# Altium schematic sheet MUX.SchDoc(MUX)
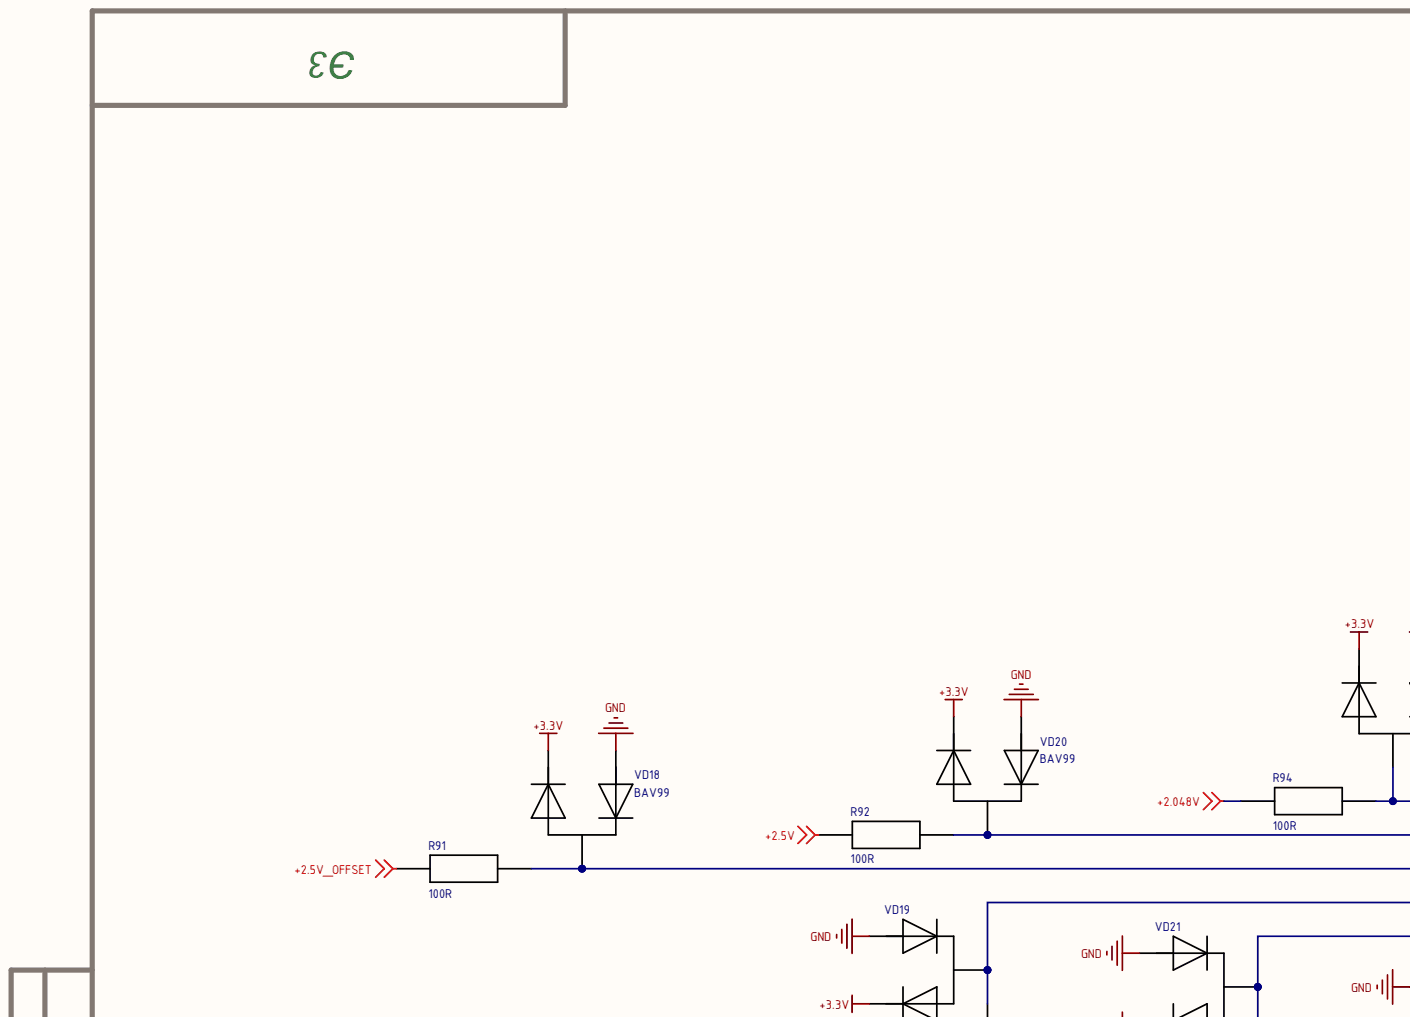
[diagram p0: MUX.SchDoc, top left]
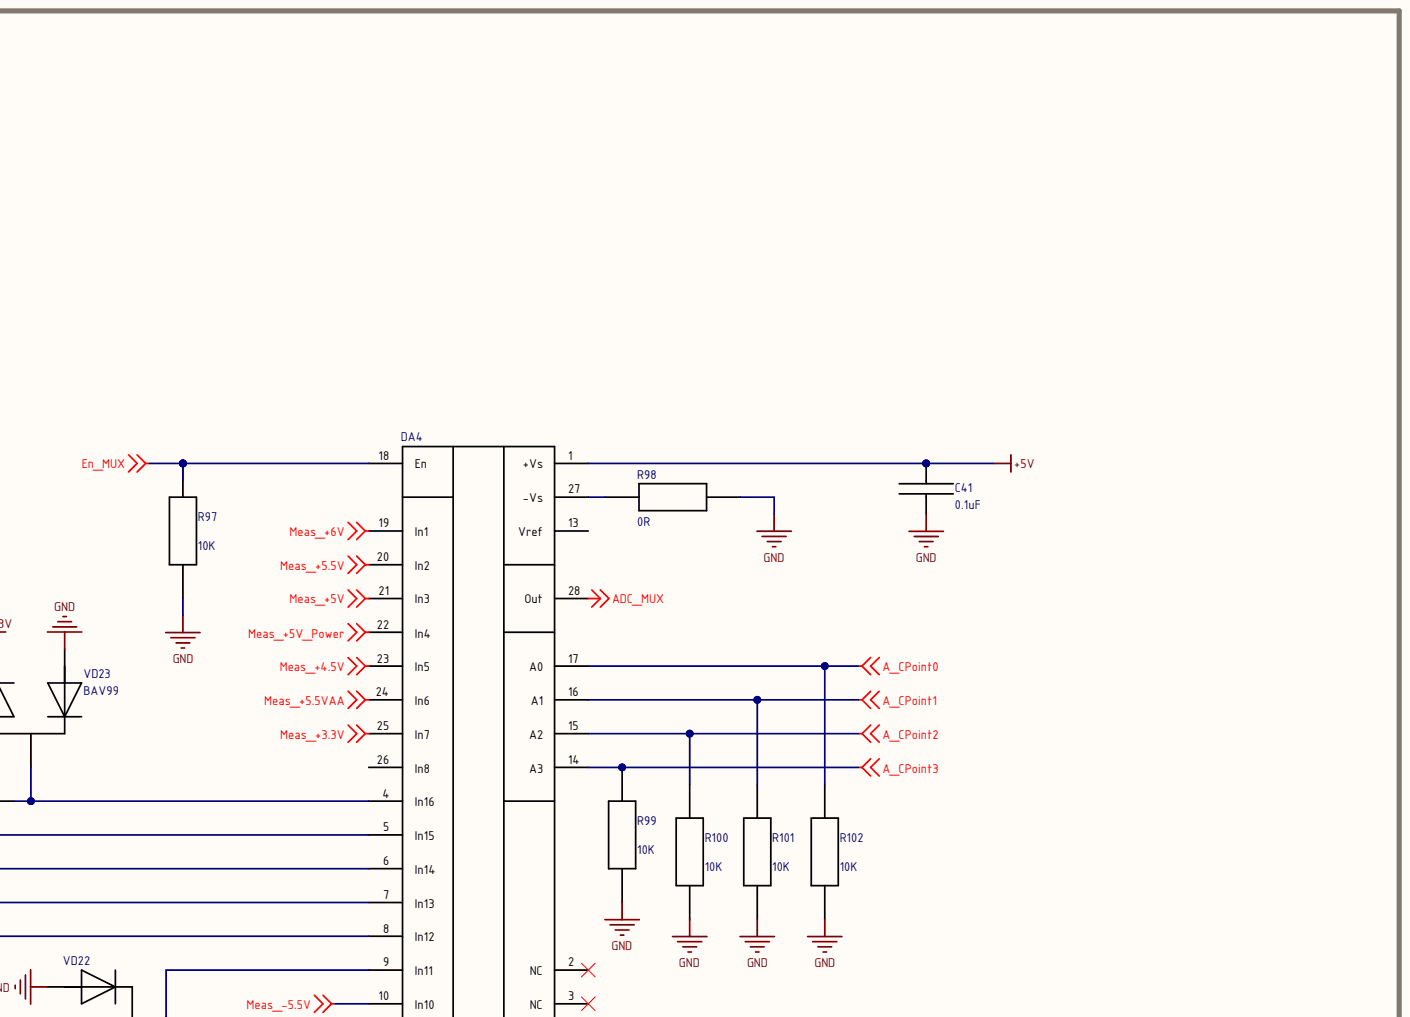
[diagram p1: MUX.SchDoc, top right]
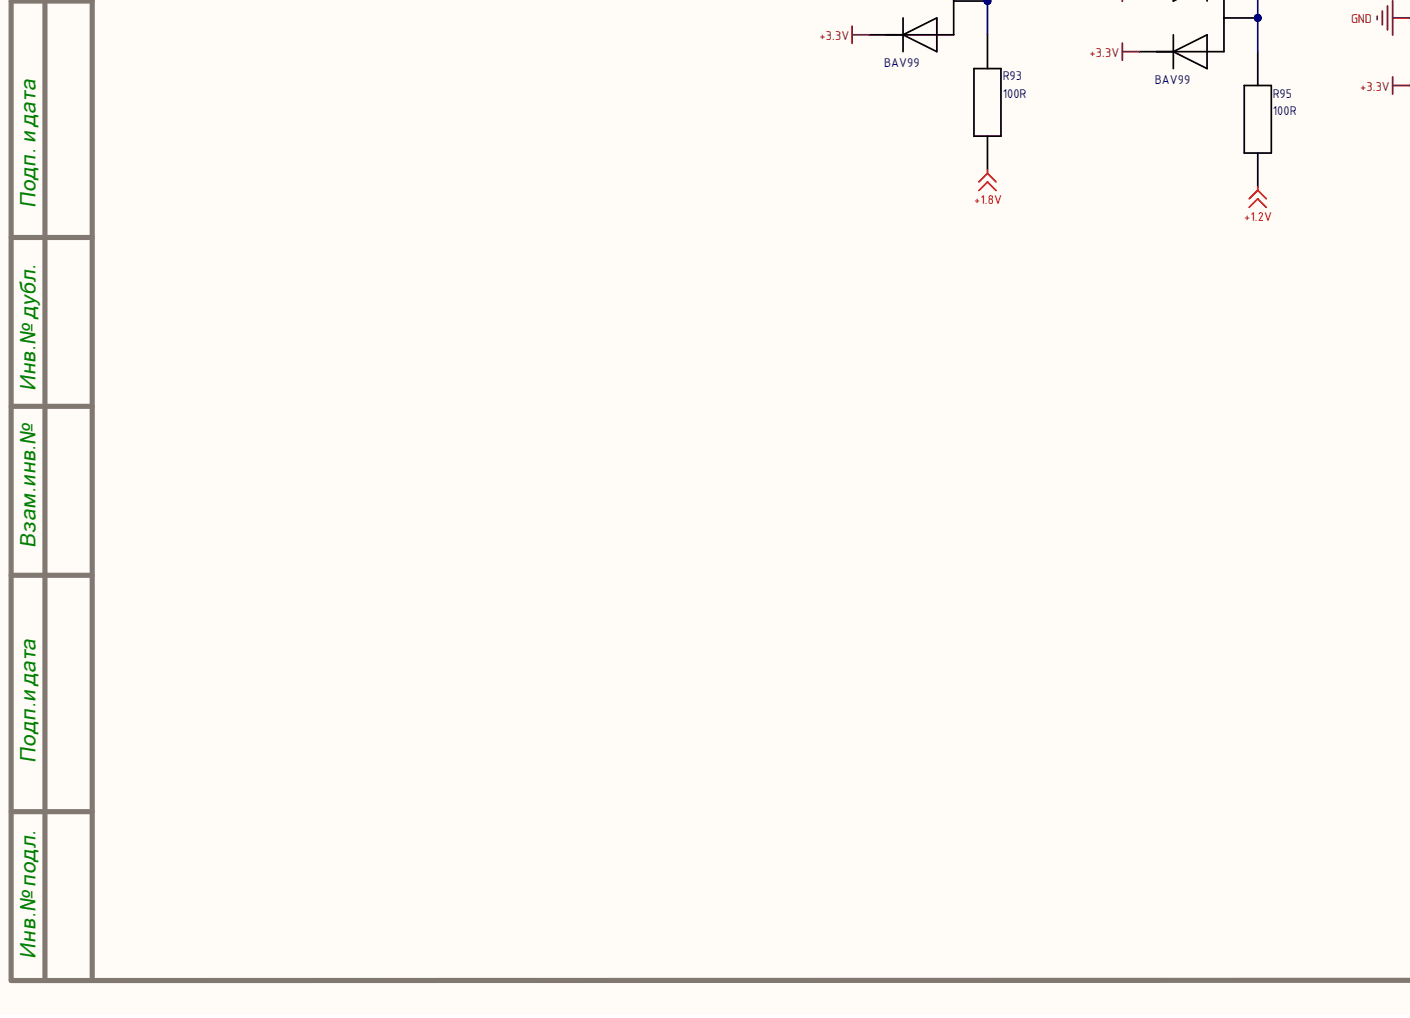
[diagram p2: MUX.SchDoc, bottom left]
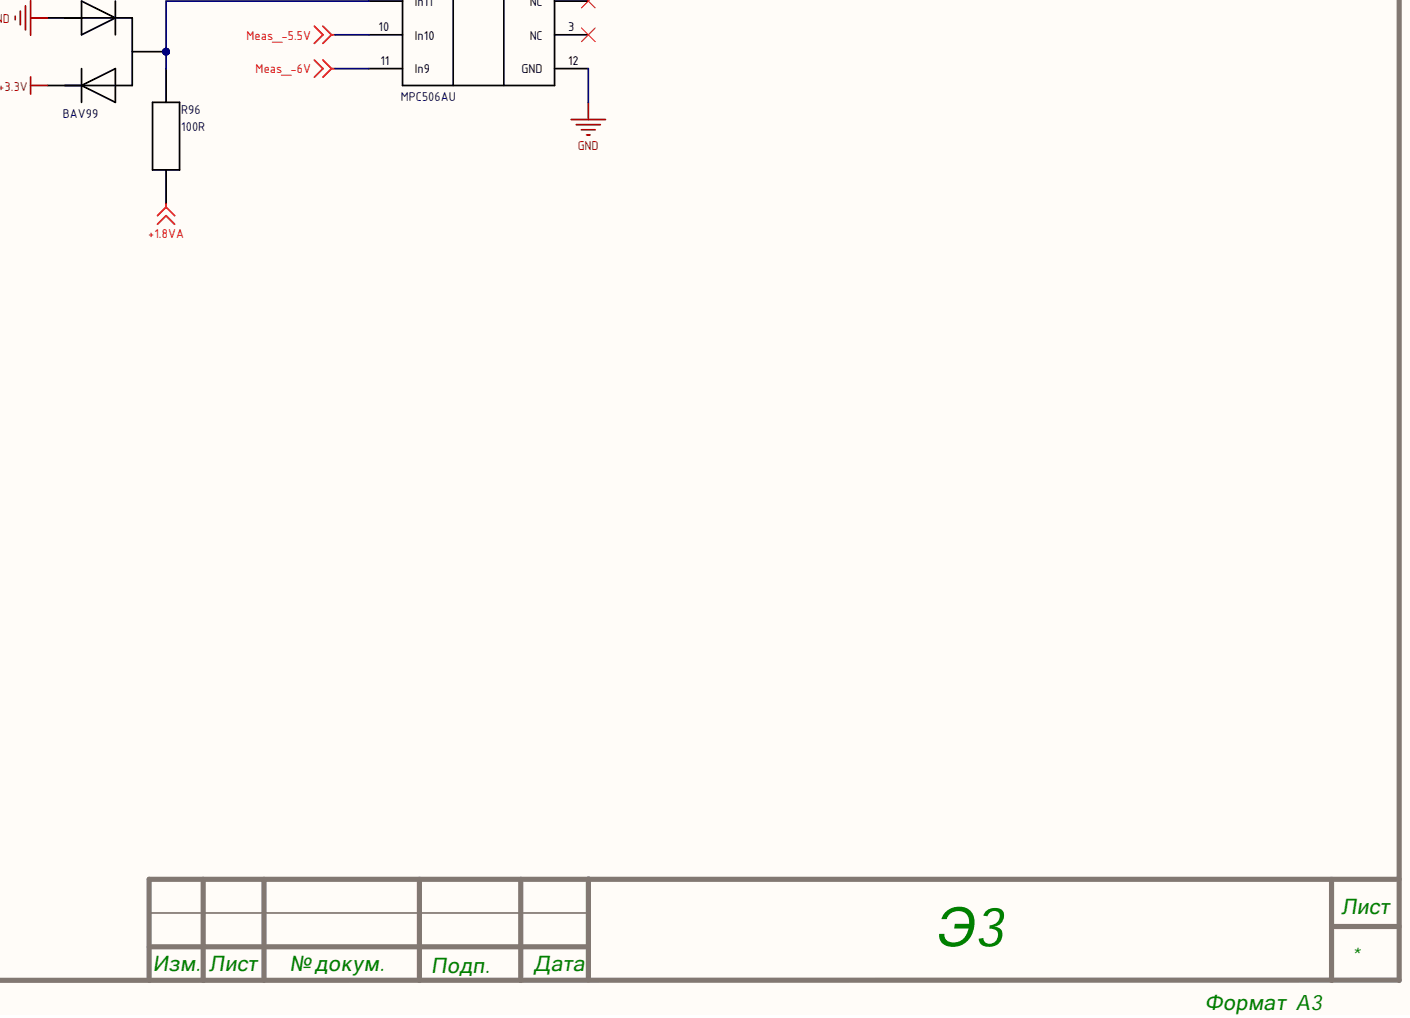
[diagram p3: MUX.SchDoc, bottom right]

## Parts
C41  0.1uF  [0603C]
DA4  MPC506AU  [SOIC-28]
R91  100R  [R0402]
R92  100R  [R0402]
R93  100R  [R0402]
R94  100R  [R0402]
R95  100R  [R0402]
R96  100R  [R0402]
R97  10K  [R0402]
R98  0R  [R0402]
R99  10K  [R0402]
R100  10K  [R0402]
R101  10K  [R0402]
R102  10K  [R0402]
VD18  BAV99  [SOT-23-3]
VD19  BAV99  [SOT-23-3]
VD20  BAV99  [SOT-23-3]
VD21  BAV99  [SOT-23-3]
VD22  BAV99  [SOT-23-3]
VD23  BAV99  [SOT-23-3]

## Nets
+1.2V: R95.1
+1.8V: R93.1
+1.8VA: R96.1
+2.5V: R92.1
+2.5V_OFFSET: R91.1
+2.048V: R94.1
+3.3V: VD18.2 VD19.2 VD20.2 VD21.2 VD22.2 VD23.2
+5V: C41.1 DA4.1(+Vs)
A_CPoint0: DA4.17(A0) R102.2
A_CPoint1: DA4.16(A1) R101.2
A_CPoint2: DA4.15(A2) R100.2
A_CPoint3: DA4.14(A3) R99.2
ADC_MUX: DA4.28(Out)
En_MUX: DA4.18(En) R97.1
GND: C41.2 DA4.12(GND) R97.2 R98.1 R99.1 R100.1 R101.1 R102.1 VD18.1 VD19.1 VD20.1 VD21.1 VD22.1 VD23.1
Meas_+3.3V: DA4.25(In7)
Meas_+4.5V: DA4.23(In5)
Meas_+5.5V: DA4.20(In2)
Meas_+5.5VAA: DA4.24(In6)
Meas_+5V: DA4.21(In3)
Meas_+5V_Power: DA4.22(In4)
Meas_+6V: DA4.19(In1)
Meas_-5.5V: DA4.10(In10)
Meas_-6V: DA4.11(In9)
NetDA4_4: DA4.4(In16) R94.2 VD23.3
NetDA4_5: DA4.5(In15) R92.2 VD20.3
NetDA4_6: DA4.6(In14) R91.2 VD18.3
NetDA4_7: DA4.7(In13) R93.2 VD19.3
NetDA4_8: DA4.8(In12) R95.2 VD21.3
NetDA4_9: DA4.9(In11) R96.2 VD22.3
NetDA4_27: DA4.27(-Vs) R98.2

Not connected: DA4 2(NC) 3(NC) 13(Vref) 26(In8)

## Library data of the parts
C41 = C0603-0.1UF 10% 50V: C0603 0.1UF 10% 50V; Part Number: SMD CAP CER 0.1UF ±10% 50V X7R 0603; Added_by: anton@teslatec.ru; Pkg: 0603; Solder_Amount: 2; Component Kind: Standard; Created_at: 10/01/2023; Mount: MOUNT; MountType: SMD; Library Name: Test_Stand_Pergam.SchLib
DA4 = MPC506AU: MPC506AU, Ch: 16, SO28; Added_by: irdolgopolowa@yandex.ru; Solder_Amount: 28; Component Kind: Standard; Created_at: 29/10/2024; Mount: MOUNT; MountType: SMD/SMT; Part Number: MPC506A; Pkg: SOIC-28; Library Name: Test_Stand_Pergam.SchLib
R91-R96 = R0402-100R: Resistor SMD 100R 1% 0402; Part Number: SMD RES 100 OHM 1% ±100ppm/°C 0402; Added_by: irdolgopolowa@yandex.ru; Pkg: 0402; Solder_Amount: 2; Component Kind: Standard; Created_at: 29/10/24; Mount: MOUNT; MountType: SMD; Library Name: Test_Stand_Pergam.SchLib
R97 R99-R102 = R0402-10K: Resistor SMD 10K 1% 0402; Part Number: SMD RES 10 KOHM 1% ±100ppm/°C 0402; Added_by: Rutel@teslatec.ru; Pkg: 0402; Solder_Amount: 2; Component Kind: Standard; Created_at: 21/04/2020; Mount: MOUNT; MountType: SMD; Library Name: Test_Stand_Pergam.SchLib
R98 = R0402-0R: Resistor SMD 0R 1% 0402; Part Number: SMD RES 0R 0402; Added_by: irdolgopolowa@yandex.ru; Pkg: 0402; Solder_Amount: 2; Component Kind: Standard; Created_at: 29/10/24; Mount: MOUNT; MountType: SMD; Library Name: Test_Stand_Pergam.SchLib
VD18-VD23 = BAV99: BAV99, UMW, 70В, 200мА; Added_by: andrew@teslatec.ru; Solder_Amount: 3; Component Kind: Standard; Created_at: 29/06/2020; Mount: MOUNT; MountType: SMD; Part Number: BAV99; Pkg: SOT-23-3; Library Name: Test_Stand_Pergam.SchLib
